# Altium schematic sheet ESP32-C3-Mini.SchDoc
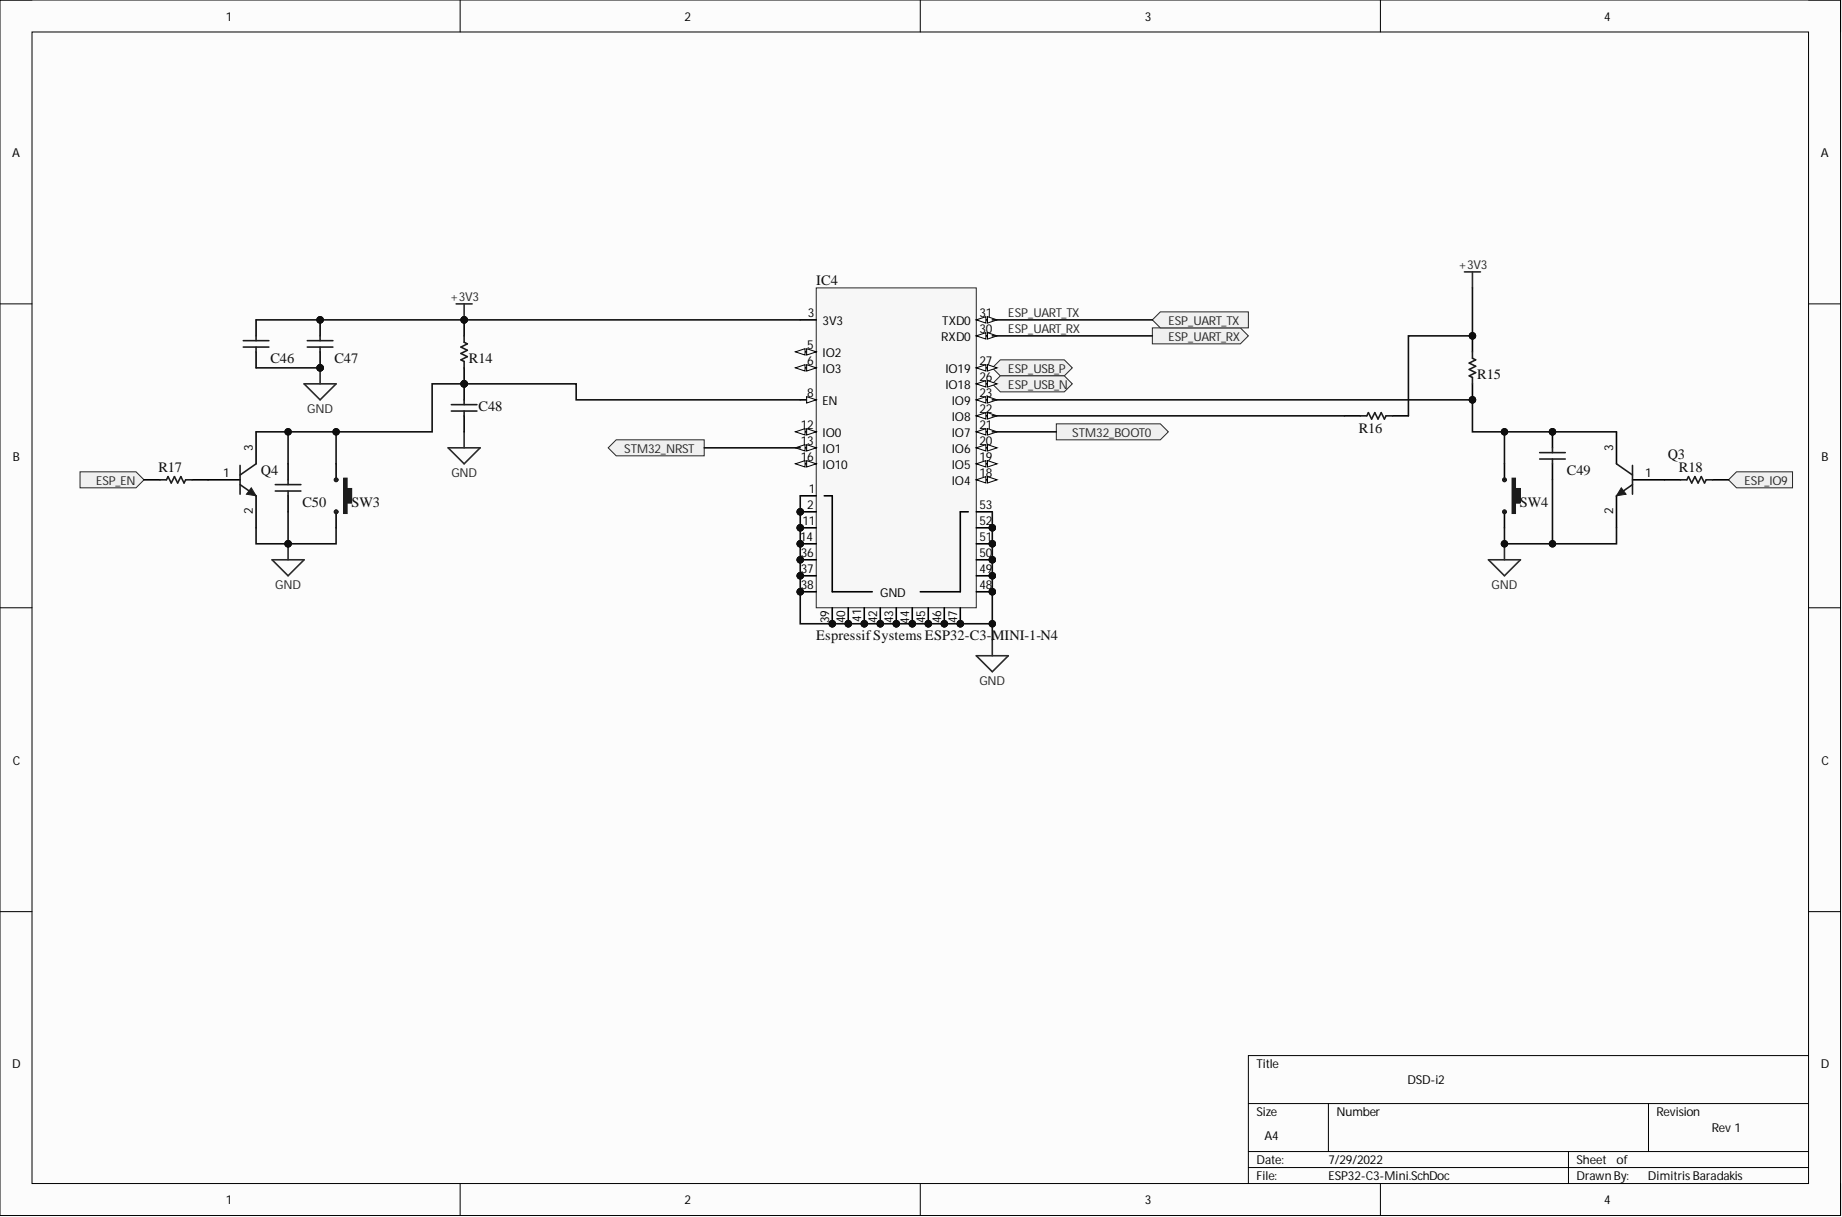
[diagram p0: ESP32-C3-Mini.SchDoc]

## Parts
C46  AVX 0402ZD106MAT2A  [AVX 0402ZD106MAT2A-Footprint-1]  (value not drawn)
C47  Taiyo Yuden LMK063BJ104KP-F  [PCB-0002-00713-1]  (value not drawn)
C48  Samsung CL03A105MO3NRNH  [Samsung CL03A105MO3NRNH-Footprint-1]  (value not drawn)
C49  Taiyo Yuden LMK063BJ104KP-F  [PCB-0002-00713-1]  (value not drawn)
C50  Taiyo Yuden LMK063BJ104KP-F  [PCB-0002-00713-1]  (value not drawn)
IC4  Espressif Systems ESP32-C3-MINI-1-N4  Manufacturer: Espressif  Manufacturer Part Number: ESP32-C3-MINI-1-N4  [PCB-k4zv3h5xtuqaodquxavs-1]
Q3  BC848BLT3G  [ONSC-SOT-23-3-318-08_V]  (value not drawn)
Q4  BC848BLT3G  [ONSC-SOT-23-3-318-08_V]  (value not drawn)
R14  Bourns CR0201AJW-103GLF  [Bourns CR0201AJW-103GLF-Footprint-1]  (value not drawn)
R15  Bourns CR0201AJW-103GLF  [Bourns CR0201AJW-103GLF-Footprint-1]  (value not drawn)
R16  Bourns CR0201AJW-103GLF  [Bourns CR0201AJW-103GLF-Footprint-1]  (value not drawn)
R17  Bourns CR0201AJW-103GLF  [Bourns CR0201AJW-103GLF-Footprint-1]  (value not drawn)
R18  Bourns CR0201AJW-103GLF  [Bourns CR0201AJW-103GLF-Footprint-1]  (value not drawn)
SW3  Panasonic EVP-BB2A9B000  [PCB-nuaqfyekbkeoejgoofz7-1]  (value not drawn)
SW4  Panasonic EVP-BB2A9B000  [PCB-nuaqfyekbkeoejgoofz7-1]  (value not drawn)

## Ports
ESP_EN, ESP_IO9, ESP_UART_RX, ESP_UART_TX, ESP_USB_N, ESP_USB_P, STM32_BOOT0, STM32_NRST

## Nets
+3V3: C46.2 C47.2 IC4.3(3V3) R14.2 R15.2 R16.1
GND: C46.1 C47.1 C48.1 C49.1 C50.1 IC4.1 IC4.2 IC4.11 IC4.14 IC4.36 IC4.37 IC4.38 IC4.39 IC4.40 IC4.41 IC4.42 IC4.43 IC4.44 IC4.45 IC4.46 IC4.47 IC4.48 IC4.49 IC4.50 IC4.51 IC4.52 IC4.53 Q3.2 Q4.2 SW3.2 SW4.2
ESP_EN: R17.1 ; port ESP_EN
ESP_IO9: R18.2 ; port ESP_IO9
ESP_UART_RX: IC4.30(RXD0) ; port ESP_UART_RX
ESP_UART_TX: IC4.31(TXD0) ; port ESP_UART_TX
ESP_USB_N: IC4.26(IO18) ; port ESP_USB_N
ESP_USB_P: IC4.27(IO19) ; port ESP_USB_P
STM32_BOOT0: IC4.21(IO7) ; port STM32_BOOT0
STM32_NRST: IC4.13(IO1) ; port STM32_NRST
NetC48_2: C48.2 C50.2 IC4.8(EN) Q4.3 R14.1 SW3.1
NetC49_2: C49.2 IC4.23(IO9) Q3.3 R15.1 SW4.1
NetIC4_22: IC4.22(IO8) R16.2
NetQ3_1: Q3.1 R18.1
NetQ4_1: Q4.1 R17.2

Not connected: IC4 5(IO2) 6(IO3) 12(IO0) 16(IO10) 18(IO4) 19(IO5) 20(IO6)

## Library data of the parts
C46 = CMP-5c4f9139b4a38388-2: Multilayer Ceramic Chip Capacitor 0402 Size 10uF 10V X5R ±20% Tolerance SMD T/R; Capacitance: 10.0 µF; Voltage Rating (DC): 10.0 V; Capacitance Tolerance: ±20%; Case/Package: 0402; Component Kind: Standard; Dielectric Characteristic: X5R; Library Name: My Personal Space; Manufacturer Part Number: 0402ZD106MAT2A; Octopart Url: https://octopart.com/0402zd106mat2a-avx-75287946; OctopartID: 5c4f9139b4a38388; RoHS: Compliant
C47 C49 C50 = CMP-e92820824df736ef-2: 0201 0.1 uF 10 V ±10% Tolerance X5R SMT Multilayer Ceramic Capacitor; Capacitance: 100 nF; Lead-Free Status: Lead Free; Voltage Rating (DC): 10.0 V; Capacitance Tolerance: ±10%; Case/Package: 0201; Component Kind: Standard; Dielectric Characteristic: X5R; Halogen Free Status: Halogen Free; Library Name: My Personal Space; Lifecycle Status: Active; Manufacturer Part Number: LMK063BJ104KP-F; Mounting Style: Surface Mount; Number of Pins: 2; Octopart URL: https://octopart.com/lmk063bj104kp-f-taiyo+yuden-10518969; OctopartID: e92820824df736ef; Operating Temperature: -55.0 °C to 85.0 °C; Packaging: Cut Tape (CT); RoHS: Compliant
C48 = CMP-0a3ac1e7a1fcd5fd-1: CL Series 0201 1 uF 16 V ±20% Tolerance X5R Multilayer Ceramic Chip Capacitor; Lead-Free Status: Lead Free; Case/Package: 0201; Component Kind: Standard; Library Name: My Personal Space; Manufacturer Part Number: CL03A105MO3NRNH; Mounting Style: Surface Mount; Octopart Url: https://octopart.com/cl03a105mo3nrnh-samsung-47681184; OctopartID: 0a3ac1e7a1fcd5fd; Packaging: Tape & Reel (TR); RoHS: Compliant
IC4 = CMP-8ad14528aa205516-6: SMD Module, ESP32-C3FN4, Pcb Ant; Component Kind: Standard; Library Name: My Personal Space; Octopart URL: https://octopart.com/esp32-c3-mini-1-n4-espressif+systems-116589975; OctopartID: 8ad14528aa205516
Q3 Q4 = CMP-860696-3: General Purpose Transistor, NPN Silicon, 3-Pin SOT-23, Pb-Free, Tape and Reel; Current Rating: 0.1 A; Lead-Free Status: Lead Free; Voltage Rating (DC): 30.0 V; Case/Package: SOT-23; Component Kind: Standard; Library Name: My Personal Space; Lifecycle Status: Active; Manufacturer Part Number: BC848BLT3G; Mounting Style: Surface Mount; Number of Pins: 3; Octopart Url: https://octopart.com/bc848blt3g-on+semiconductor-835077; OctopartID: 31b1f8b7aad2ee76; Packaging: Tape; Polarity: NPN; RoHS: Compliant
R14-R18 = CMP-0c15c370377fd3d2-2: Thick Film Chip Resistor; 0201 10k 5% 50mW TC200; Component Kind: Standard; Library Name: My Personal Space; Manufacturer Part Number: CR0201AJW-103GLF; Octopart Url: https://octopart.com/cr0201ajw-103glf-bourns-107945484; OctopartID: 0c15c370377fd3d2
SW3 SW4 = CMP-57ca9abfc056880d-3: Switch Tactile N.O. SPST Round Button Gull Wing 0.02A 15VDC 500000Cycles 1.6N SMD T/R; Lead-Free Status: Lead Free; Component Kind: Standard; Library Name: My Personal Space; Lifecycle Status: Not Listed by Manufacturer; Manufacturer Part Number: EVP-BB2A9B000; Octopart URL: https://octopart.com/evp-bb2a9b000-panasonic-75477754; OctopartID: 57ca9abfc056880d; RoHS: Compliant
